# Altium schematic sheet P07_Memory.SchDoc
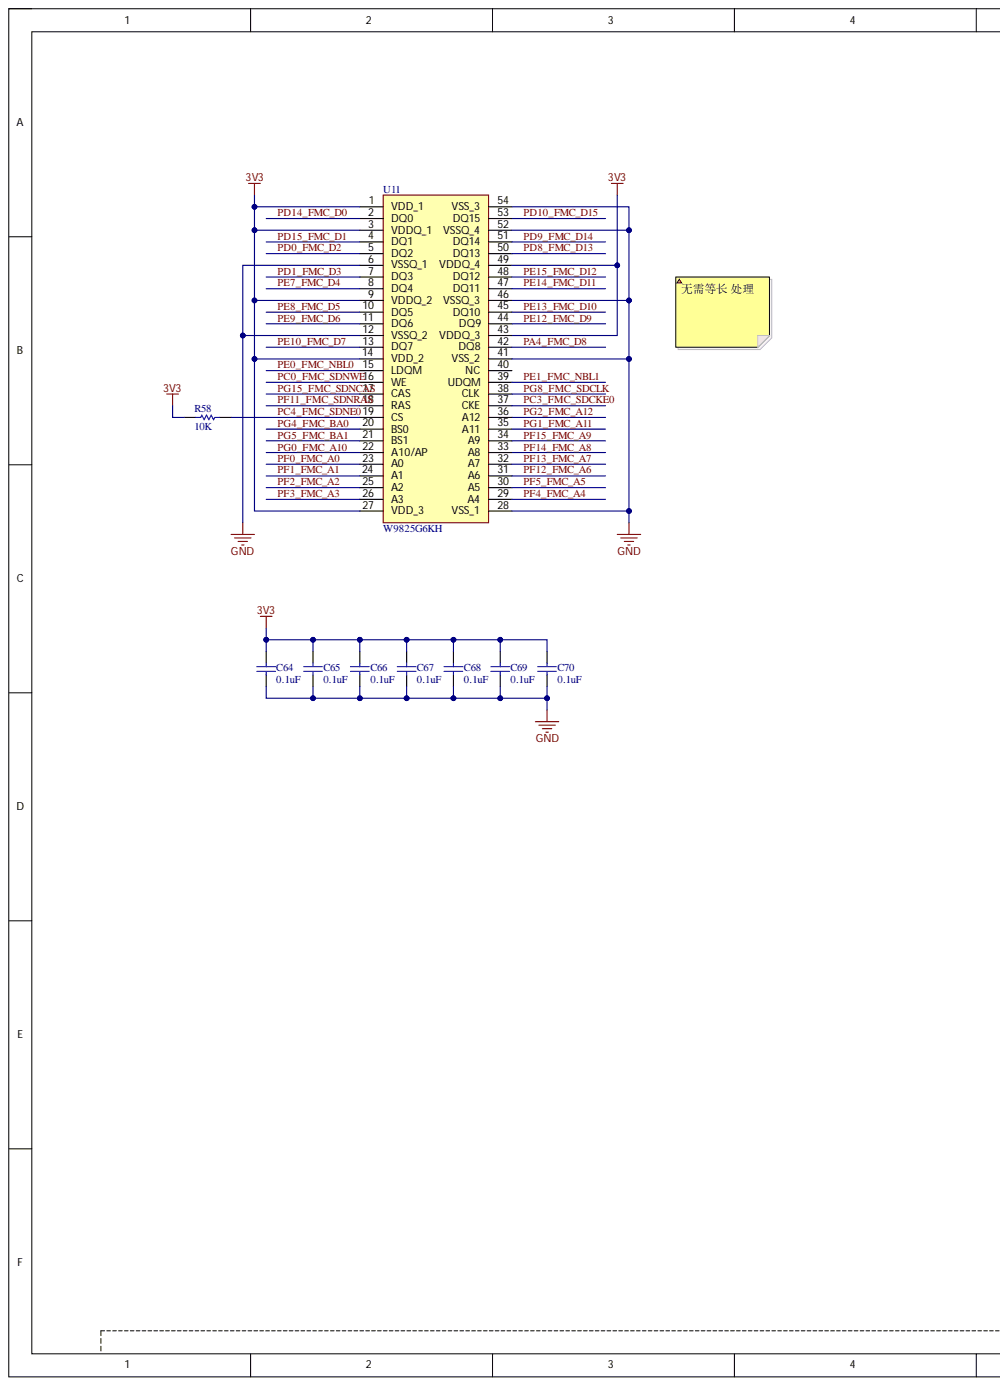
[diagram p0: P07_Memory.SchDoc, left]
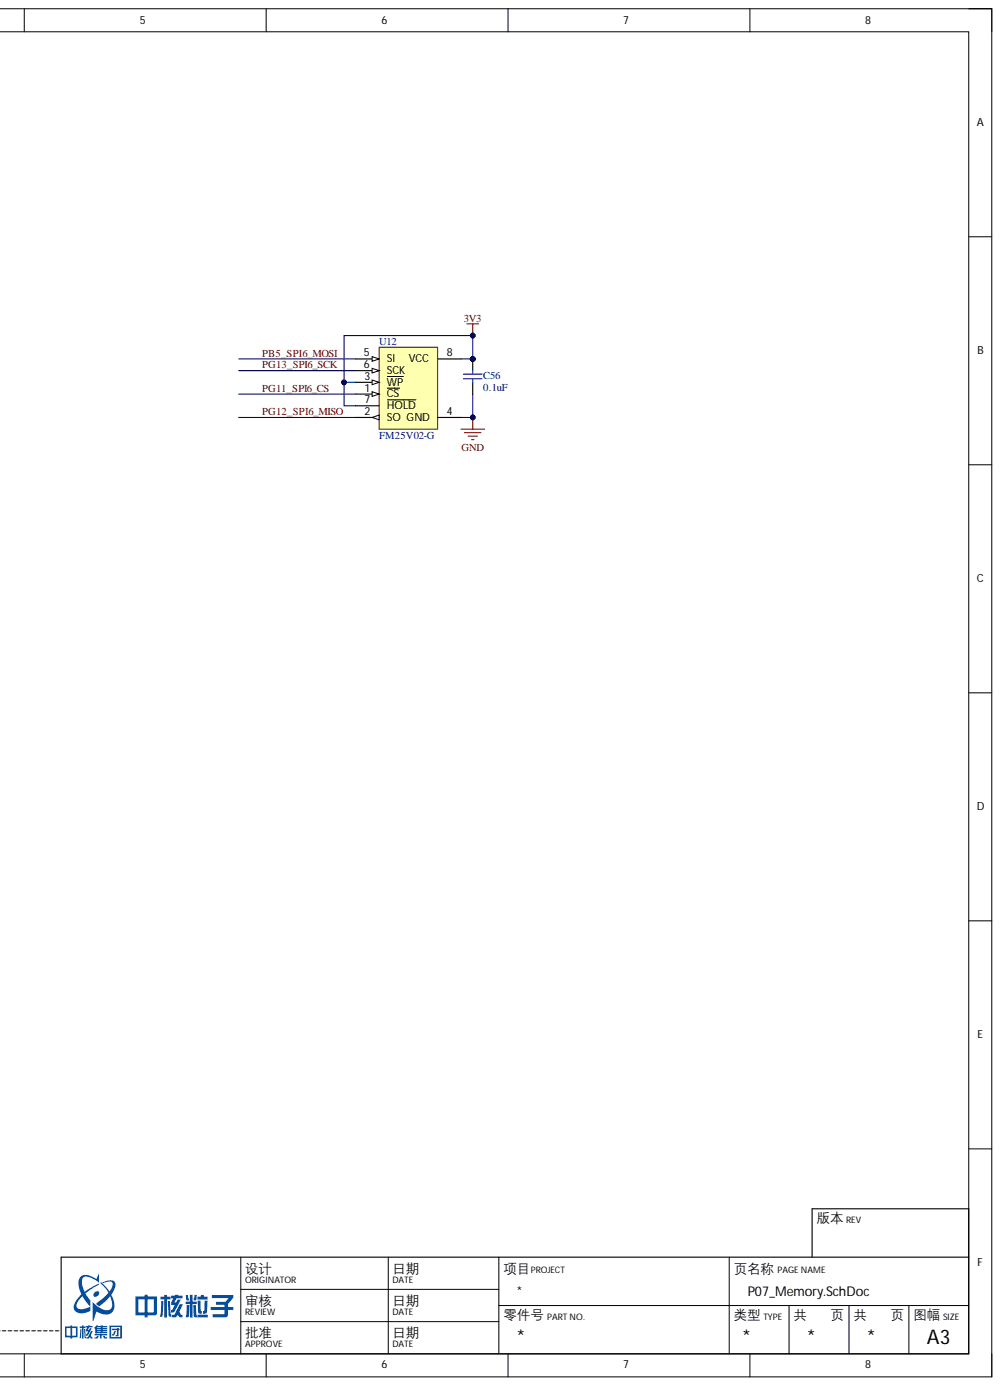
[diagram p1: P07_Memory.SchDoc, right]

## Parts
C56  0.1uF  [C0603(1608)]
C64  0.1uF  [C0603(1608)]
C65  0.1uF  [C0603(1608)]
C66  0.1uF  [C0603(1608)]
C67  0.1uF  [C0603(1608)]
C68  0.1uF  [C0603(1608)]
C69  0.1uF  [C0603(1608)]
C70  0.1uF  [C0603(1608)]
R58  10K  [R0603(1608)]
U11  W9825G6KH  [SOP80P1176X120-54N]
U12  FM25V02-G  [FM25V02-G_SOP8]

## Nets
3V3: C56.1 C64.2 C65.2 C66.2 C67.2 C68.2 C69.2 C70.2 R58.1 U11.1(VDD_1) U11.3(VDDQ_1) U11.9(VDDQ_2) U11.14(VDD_2) U11.27(VDD_3) U11.43(VDDQ_3) U11.49(VDDQ_4) U12.3(WP) U12.7(HOLD) U12.8(VCC)
GND: C56.2 C64.1 C65.1 C66.1 C67.1 C68.1 C69.1 C70.1 U11.6(VSSQ_1) U11.12(VSSQ_2) U11.28(VSS_1) U11.41(VSS_2) U11.46(VSSQ_3) U11.52(VSSQ_4) U11.54(VSS_3) U12.4(GND)
PA4_FMC_D8: U11.42(DQ8)
PB5_SPI6_MOSI: U12.5(SI)
PC0_FMC_SDNWE: U11.16(WE)
PC3_FMC_SDCKE0: U11.37(CKE)
PC4_FMC_SDNE0: R58.2 U11.19(CS)
PD0_FMC_D2: U11.5(DQ2)
PD1_FMC_D3: U11.7(DQ3)
PD8_FMC_D13: U11.50(DQ13)
PD9_FMC_D14: U11.51(DQ14)
PD10_FMC_D15: U11.53(DQ15)
PD14_FMC_D0: U11.2(DQ0)
PD15_FMC_D1: U11.4(DQ1)
PE0_FMC_NBL0: U11.15(LDQM)
PE1_FMC_NBL1: U11.39(UDQM)
PE7_FMC_D4: U11.8(DQ4)
PE8_FMC_D5: U11.10(DQ5)
PE9_FMC_D6: U11.11(DQ6)
PE10_FMC_D7: U11.13(DQ7)
PE12_FMC_D9: U11.44(DQ9)
PE13_FMC_D10: U11.45(DQ10)
PE14_FMC_D11: U11.47(DQ11)
PE15_FMC_D12: U11.48(DQ12)
PF0_FMC_A0: U11.23(A0)
PF1_FMC_A1: U11.24(A1)
PF2_FMC_A2: U11.25(A2)
PF3_FMC_A3: U11.26(A3)
PF4_FMC_A4: U11.29(A4)
PF5_FMC_A5: U11.30(A5)
PF11_FMC_SDNRAS: U11.18(RAS)
PF12_FMC_A6: U11.31(A6)
PF13_FMC_A7: U11.32(A7)
PF14_FMC_A8: U11.33(A8)
PF15_FMC_A9: U11.34(A9)
PG0_FMC_A10: U11.22(A10/AP)
PG1_FMC_A11: U11.35(A11)
PG2_FMC_A12: U11.36(A12)
PG4_FMC_BA0: U11.20(BS0)
PG5_FMC_BA1: U11.21(BS1)
PG8_FMC_SDCLK: U11.38(CLK)
PG11_SPI6_CS: U12.1(CS)
PG12_SPI6_MISO: U12.2(SO)
PG13_SPI6_SCK: U12.6(SCK)
PG15_FMC_SDNCAS: U11.17(CAS)

Not connected: U11 40(NC)

## Library data of the parts
C56 C64-C70 = Cap 0.1uF 0603: ceramic 25V; 价格: ￥0.092@100; 制造商: KEMET; 型号: C0603C104K3RACTU; Component Kind: Standard; Library Name: RCL.SchLib; 供应商及库存编号: e络盟 1650835
R58 = Res 10K 0603: Res ±1%; 名称: 贴片电阻; Component Kind: Standard; Library Name: RCL.SchLib
U11 = W9825G6KH: DRAM 256M SDR SDRAM x16; Component Kind: Standard; Library Name: IC2022.SchLib
U12 = FM25V02-G: Component Kind: Standard; Description: FM25V02-G; Library Name: IC2023.SchLib
